# Altium schematic sheet Control block.SchDoc
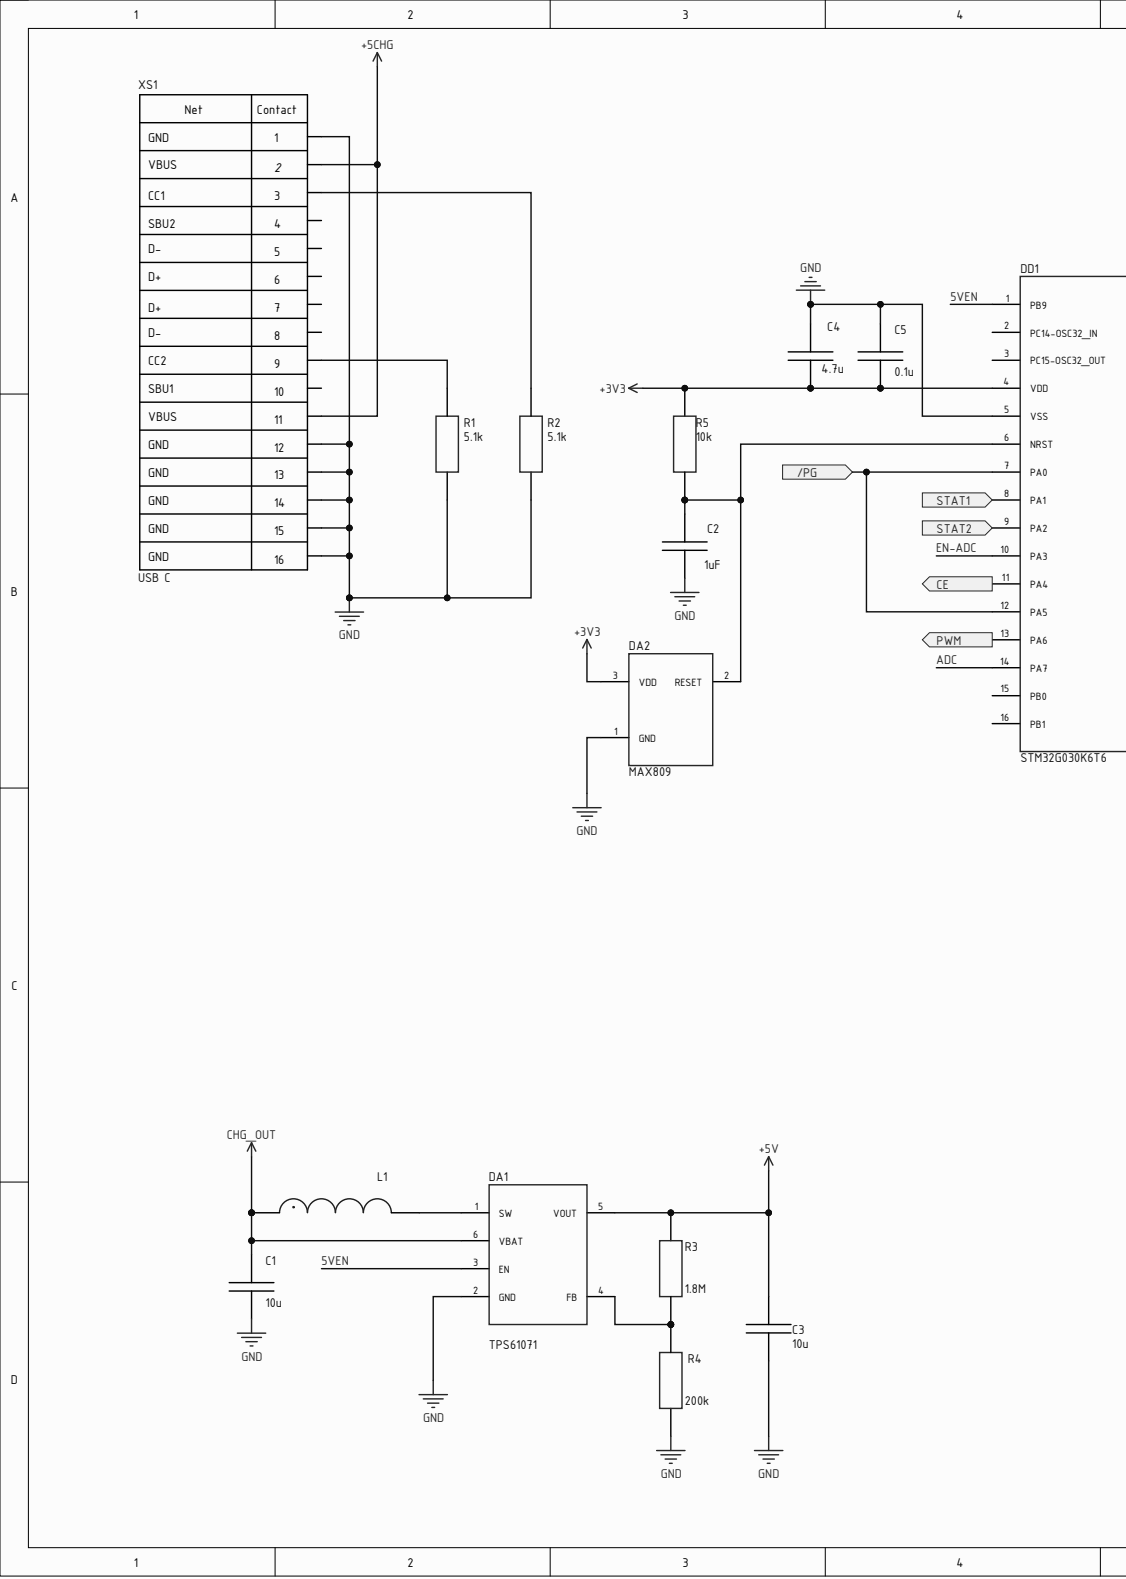
[diagram p0: Control block.SchDoc, left]
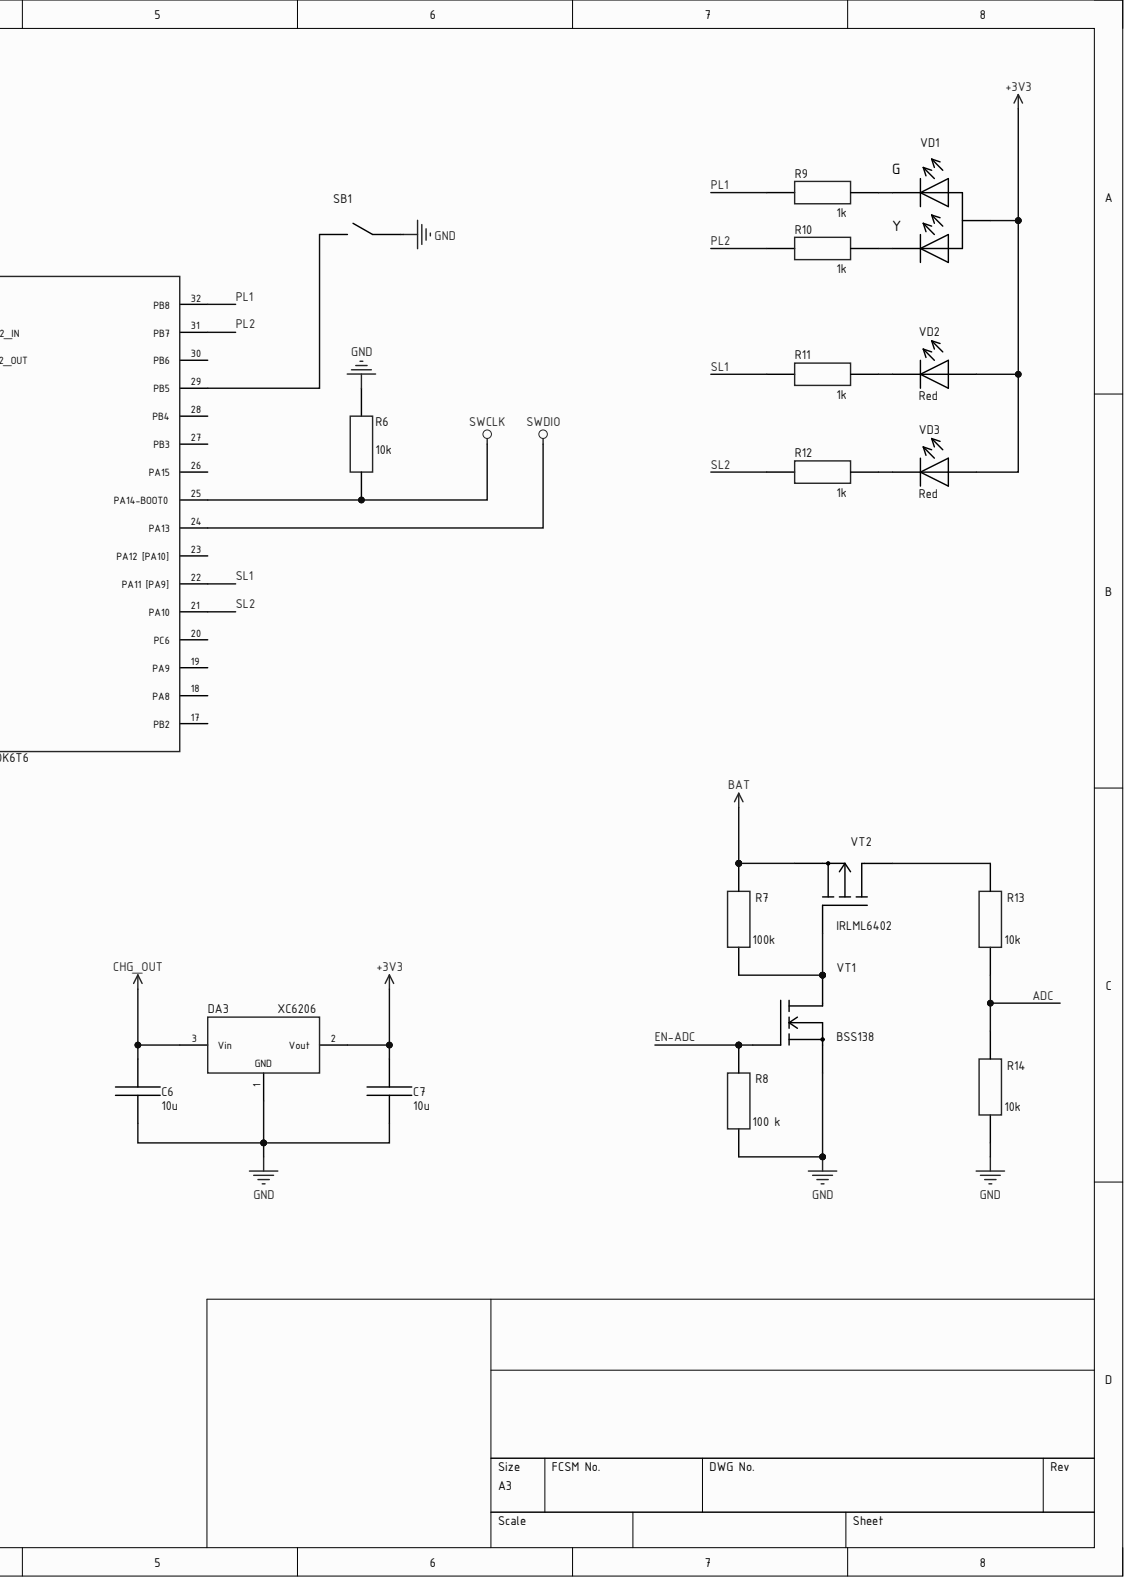
[diagram p1: Control block.SchDoc, right]

## Parts
C1  10u  [C0402]
C2  1uF  [C0402]
C3  10u  [C0402]
C4  4.7u  [C0402]
C5  0.1u  [C0402]
C6  10u  [C0402]
C7  10u  [C0402]
DA1  TPS61071  [SOT23-6]
DA2  MAX809  [SOT23-3]
DA3  XC6206  [SOT23-3]
DD1  STM32G030K6T6  [LQFP32]
L1  Inductor  [LQH32CN100K23]  (value not drawn)
R1  5.1k  [0603]
R2  5.1k  [0603]
R3  1.8M  [0402]
R4  200k  [0402]
R5  10k  [0402]
R6  10k  [0402]
R7  100k  [0402]
R8  100 k  [0402]
R9  1k  [0402]
R10  1k  [0402]
R11  1k  [0402]
R12  1k  [0402]
R13  10k  [0402]
R14  10k  [0402]
SB1  Button  [KLS7-TS3606]  (value not drawn)
VD1  LED Duble  [APBA3010SYKCGKC-GX]  (value not drawn)
VD2  Red  [KPA-3010SGC]
VD3  Red  [KPA-3010SGC]
VT1  BSS138  [SOT23-3]
VT2  IRLML6402  [SOT23-3]
XS1  USB C  [USB C 16]

## Ports
/PG, CE, PWM, STAT1, STAT2

## Nets
+3V3: C4.1 C5.1 C7.2 DA2.3 DA3.2 DD1.4(VDD) R5.1 VD1.1 VD2.2 VD3.2
BAT: R7.2 VT2.2
CHG_OUT: C1.2 C6.2 DA1.6(VBAT) DA3.3 L1.1
GND: C1.1 C2.1 C3.1 C4.2 C5.2 C6.1 C7.1 DA1.2(GND) DA2.1 DA3.1 DD1.5(VSS) R1.1 R2.1 R4.1 R6.1 R8.1 R14.1 SB1.1 VT1.2 XS1.1 XS1.12 XS1.13 XS1.14 XS1.15 XS1.16
SWCLK: DD1.25(PA14-BOOT0) R6.2
SWDIO: DD1.24(PA13)
5VEN: C3.2 DA1.3(EN) DA1.5(VOUT) DD1.1(PB9) R3.1 XS1.2 XS1.11
/PG: DD1.7(PA0) DD1.12(PA5) ; port /PG
ADC: DD1.14(PA7) R13.1 R14.2
CE: DD1.11(PA4) ; port CE
EN-ADC: DD1.10(PA3) R8.2 VT1.1
PL1: DD1.32(PB8) R9.1
PL2: DD1.31(PB7) R10.1
PWM: DD1.13(PA6) ; port PWM
SL1: DD1.22([PA9]) R11.1
SL2: DD1.21(PA10) R12.1
STAT1: DD1.8(PA1) ; port STAT1
STAT2: DD1.9(PA2) ; port STAT2
NetC2_2: C2.2 DA2.2 DD1.6(NRST) R5.2
NetDA1_1: DA1.1(SW) L1.2
NetDA1_4: DA1.4(FB) R3.2 R4.2
NetDD1_29: DD1.29(PB5) SB1.2
NetR1_2: R1.2 XS1.9
NetR2_2: R2.2 XS1.3
NetR7_1: R7.1 VT1.3 VT2.1
NetR9_2: R9.2 VD1.3
NetR10_2: R10.2 VD1.2
NetR11_2: R11.2 VD2.1
NetR12_2: R12.2 VD3.1
NetR13_2: R13.2 VT2.3

Not connected: DD1 2(PC14-OSC32_IN) 3(PC15-OSC32_OUT) 15(PB0) 16(PB1) 17(PB2) 18(PA8) 19(PA9) 20(PC6) 23([PA10]) 26(PA15) 27(PB3) 28(PB4) 30(PB6); XS1 4 5 6 7 8 10

## Library data of the parts
C1-C7 = Capacitor: Component Kind: Standard; Library Name: for_Lamp.SchLib
DA1 = TPS6107x: Efficient Synchronous Boost Converter; Component Kind: Standard; Library Name: for_Lamp.SchLib
DA3 = LDO XC6206: Component Kind: Standard; Library Name: for_Lamp.SchLib
R1-R14 = Resistor: Component Kind: Standard; Library Name: for_Lamp.SchLib
VD2 VD3 = LED: Component Kind: Standard; Library Name: for_Lamp.SchLib
VT1 = N-MOSFET: Component Kind: Standard; Library Name: for_Lamp.SchLib
VT2 = P-MOSFET: Component Kind: Standard; Library Name: for_Lamp.SchLib
XS1 = USB C 16: Component Kind: Standard; Library Name: for_Lamp.SchLib
DA2 = MAX809: Component Kind: Standard; Library Name: for_Lamp.SchLib
DD1 = STM32G030K6T6: Component Kind: Standard; Library Name: for_Lamp.SchLib
L1 = Inductor: Component Kind: Standard; Library Name: for_Lamp.SchLib
SB1 = Button: Component Kind: Standard; Library Name: for_Lamp.SchLib
VD1 = LED Duble: Component Kind: Standard; Library Name: for_Lamp.SchLib
